# Altium schematic sheet Sheet4.SchDoc
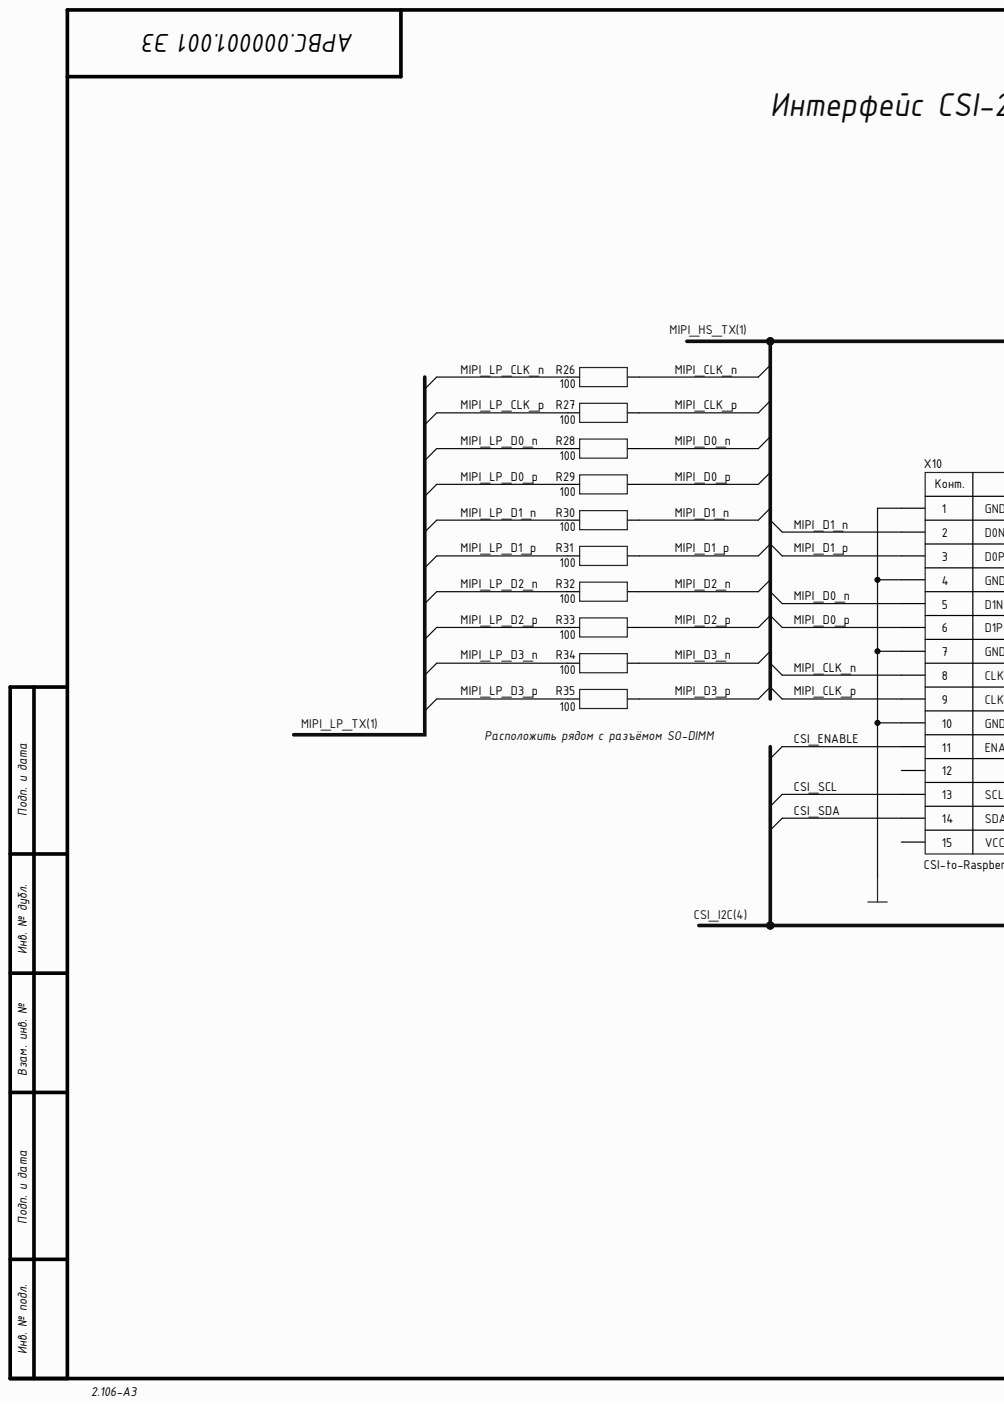
[diagram p0: Sheet4.SchDoc, left]
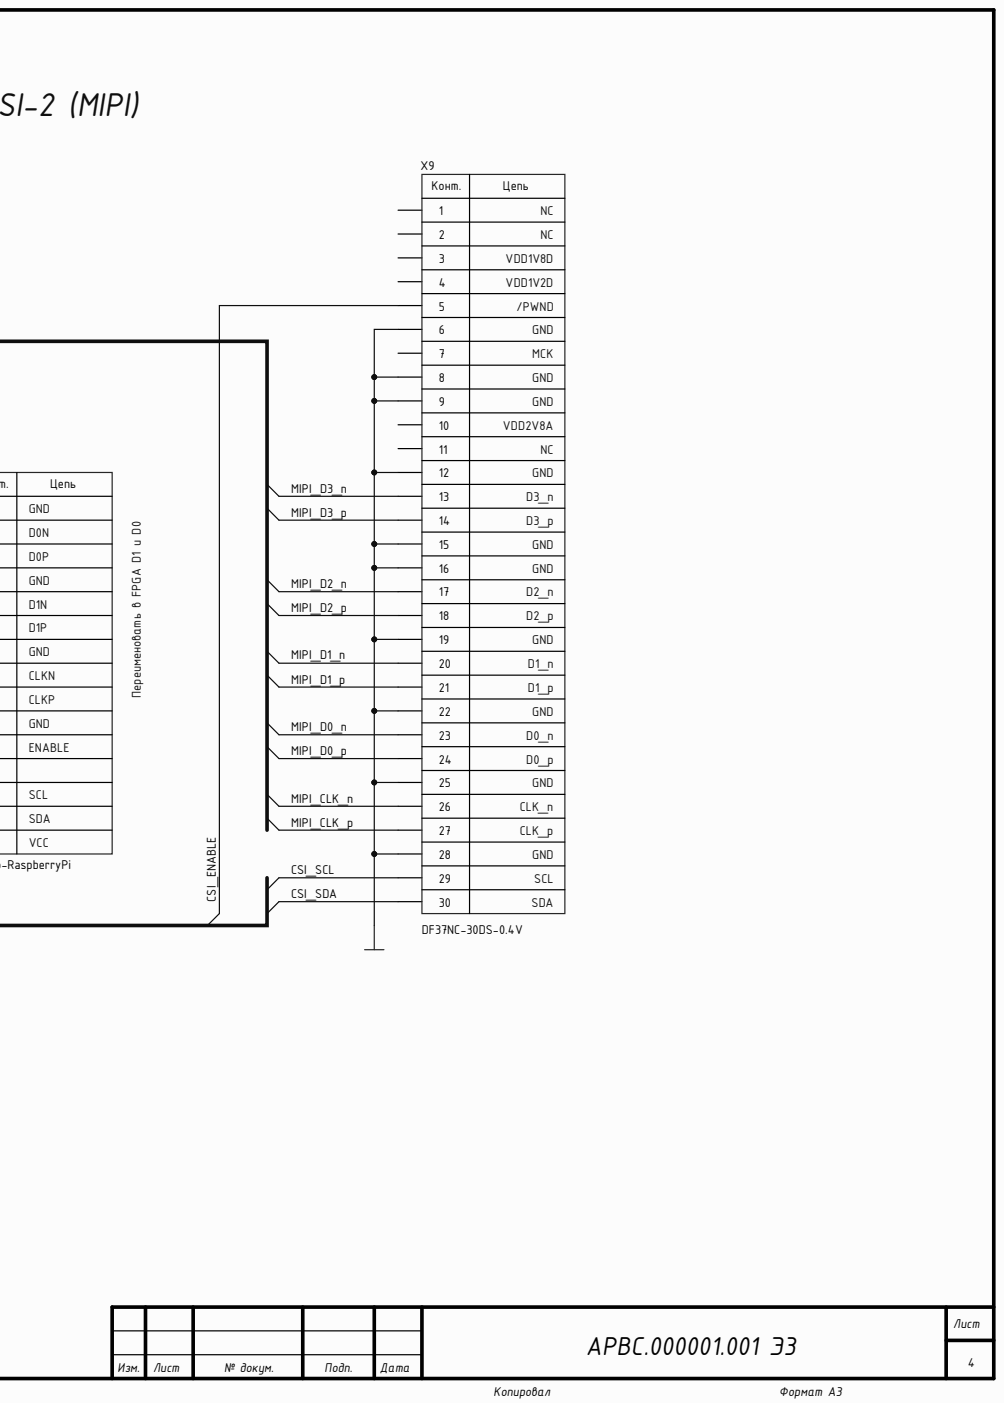
[diagram p1: Sheet4.SchDoc, right]

## Parts
R26  value 100  [RES: 0402]  (hidden comment: Resistor film, 100, ±5%, 0402, 0.063W, -55...+125°C)
R27  value 100  [RES: 0402]  (hidden comment: Resistor film, 100, ±5%, 0402, 0.063W, -55...+125°C)
R28  value 100  [RES: 0402]  (hidden comment: Resistor film, 100, ±5%, 0402, 0.063W, -55...+125°C)
R29  value 100  [RES: 0402]  (hidden comment: Resistor film, 100, ±5%, 0402, 0.063W, -55...+125°C)
R30  value 100  [RES: 0402]  (hidden comment: Resistor film, 100, ±5%, 0402, 0.063W, -55...+125°C)
R31  value 100  [RES: 0402]  (hidden comment: Resistor film, 100, ±5%, 0402, 0.063W, -55...+125°C)
R32  value 100  [RES: 0402]  (hidden comment: Resistor film, 100, ±5%, 0402, 0.063W, -55...+125°C)
R33  value 100  [RES: 0402]  (hidden comment: Resistor film, 100, ±5%, 0402, 0.063W, -55...+125°C)
R34  value 100  [RES: 0402]  (hidden comment: Resistor film, 100, ±5%, 0402, 0.063W, -55...+125°C)
R35  value 100  [RES: 0402]  (hidden comment: Resistor film, 100, ±5%, 0402, 0.063W, -55...+125°C)
X9  PartNumber: DF37NC-30DS-0.4V  [CON: DF37NC-30DP-0.4]  (hidden comment: Connector, 30pin, 2.54mm, 500VAC, 3A)
X10  CommentText: CSI-to-RaspberryPi  [CON: SFW15R-1STE1LF (FPC-15)]  (hidden comment: FPC/FFC, 40pin, 0.5mm)

## Nets
GND: X9.6 X9.8 X9.9 X9.12 X9.15 X9.16 X9.19 X9.22 X9.25 X9.28 X10.1 X10.4 X10.7 X10.10
CSI_ENABLE: X9.5 X10.11
CSI_SCL: X9.29 X10.13
CSI_SDA: X9.30 X10.14
MIPI_CLK_n: R26.2 X9.26 X10.8
MIPI_CLK_p: R27.2 X9.27 X10.9
MIPI_D0_n: R28.2 X9.23 X10.5
MIPI_D0_p: R29.2 X9.24 X10.6
MIPI_D1_n: R30.2 X9.20 X10.2
MIPI_D1_p: R31.2 X9.21 X10.3
MIPI_D2_n: R32.2 X9.17
MIPI_D2_p: R33.2 X9.18
MIPI_D3_n: R34.2 X9.13
MIPI_D3_p: R35.2 X9.14
MIPI_LP_CLK_n: R26.1
MIPI_LP_CLK_p: R27.1
MIPI_LP_D0_n: R28.1
MIPI_LP_D0_p: R29.1
MIPI_LP_D1_n: R30.1
MIPI_LP_D1_p: R31.1
MIPI_LP_D2_n: R32.1
MIPI_LP_D2_p: R33.1
MIPI_LP_D3_n: R34.1
MIPI_LP_D3_p: R35.1

Not connected: X9 1 2 3 4 7 10 11; X10 12 15

## Library data of the parts
R26-R35 = Resistor_0402: Manufacturer: Yageo; PartNumber: RC0402JR-07100RL; Component Kind: Standard; HelpURL: https://www.digikey.com/product-detail/en/yageo/RC0402JR-07100RL/311-100JRCT-ND/729362; Library Name: Resistor.IntLib; Package: 0402; Power: 0.063W; Temperature: -55...+125°C; Tolerance: ±5%; TypeResistor: Resistor film; ValueManufacturerPartNumber: Resistor film, 100, ±5%, 0402, 0.063W, -55...+125°C
X9 = DF37NC-30DP-0.4: Manufacturer: Connfly; CommentText: "Разъем"; Component Kind: Standard; CurrentMax: 3A; HelpURL: https://www.chipdip.ru/product/pls-40r-2; Library Name: Connector.IntLib; Multichannel: 30pin; Pitch: 2.54mm; TypeConnector: Connector; ValueManufacturerPartNumber: Connector, 30pin, 2.54mm, 500VAC, 3A; VoltageMax: 500VAC
X10 = SFW15R-1STE1LF: Manufacturer: FCIconnect; PartNumber: F1003WR-S-15PNLNG1GB0R (HR(JTE)); Component Kind: Standard; HelpURL: https://www.compel.ru/infosheet/AML/SFW15R-1STE1LF; Library Name: Connector.IntLib; Multichannel: 40pin; Pitch: 0.5mm; TypeConnector: FPC/FFC; ValueManufacturerPartNumber: FPC/FFC, 40pin, 0.5mm
